# Altium schematic sheet SensorMod_Interface.SchDoc(SensorMod_Interface)
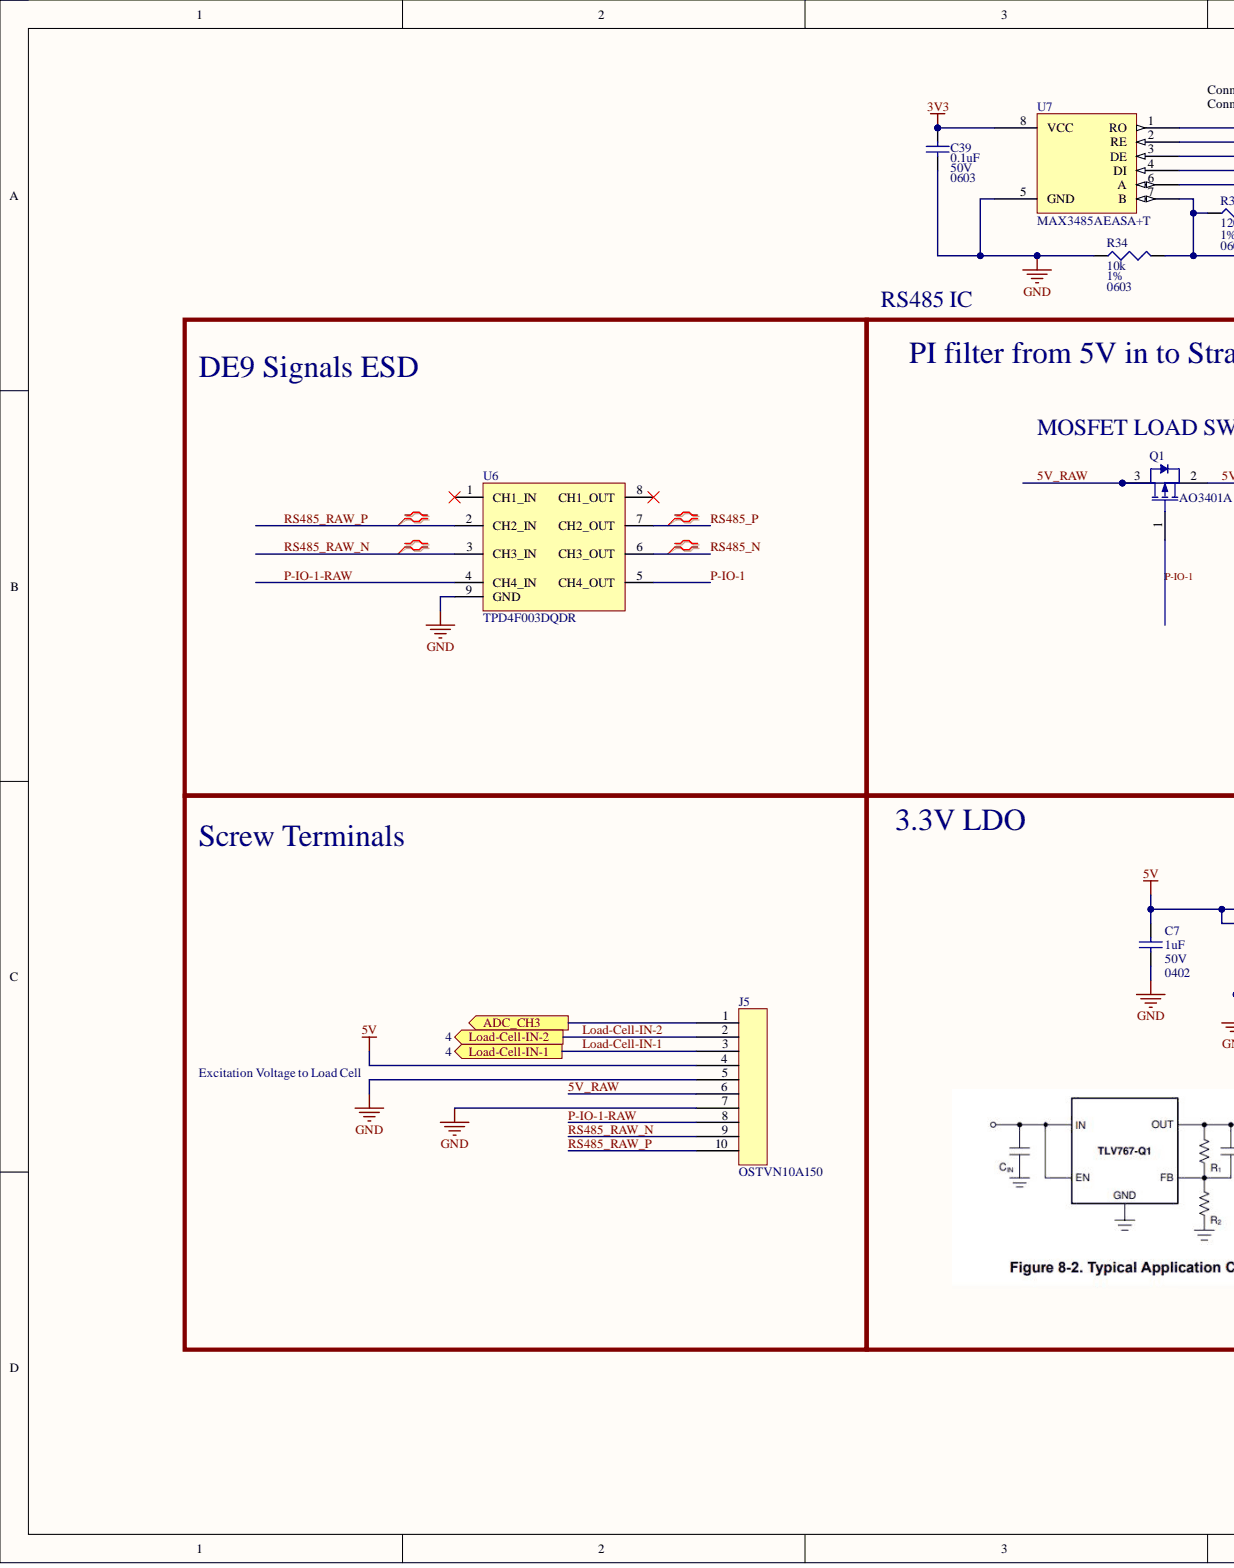
[diagram p0: SensorMod_Interface.SchDoc, left]
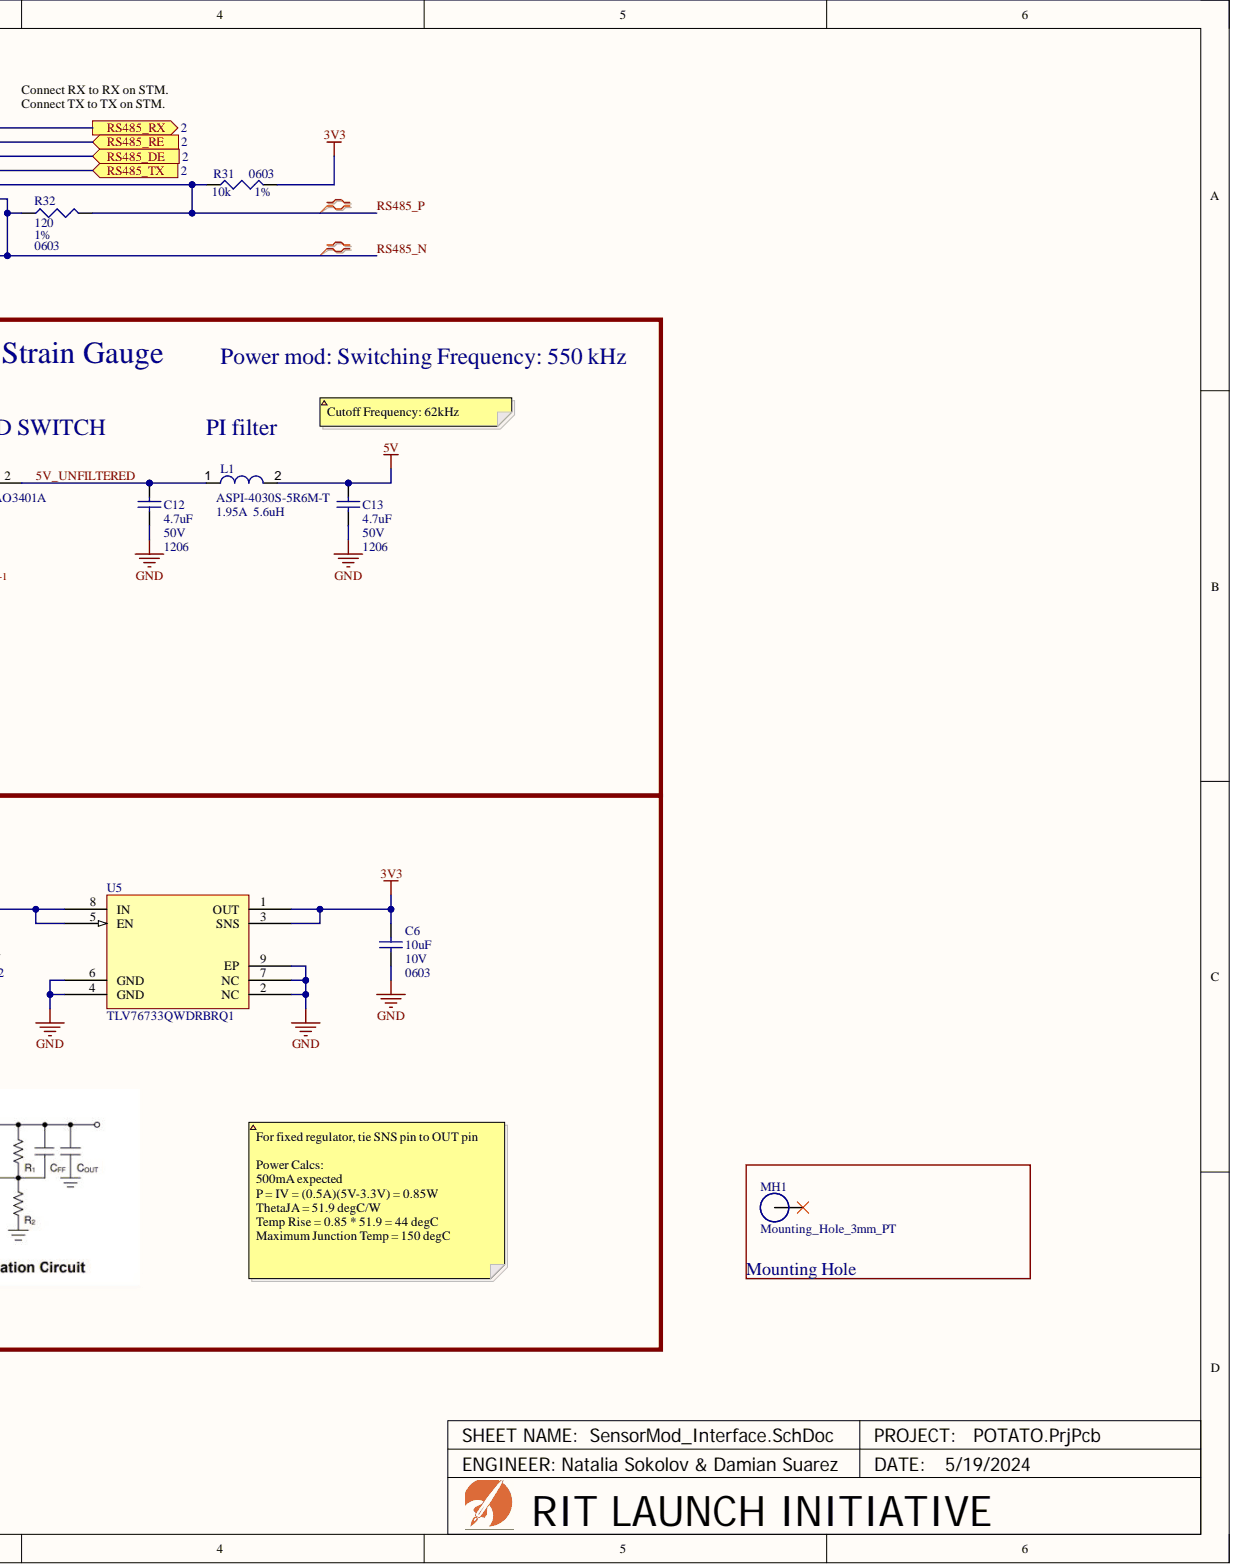
[diagram p1: SensorMod_Interface.SchDoc, right]

## Parts
C6  Capacitance: 10uF  Case/Package: 0603  Voltage: 10V  (hidden comment: CL10A106KP8NNNC)
C7  Capacitance: 1uF  Case/Package: 0402  Voltage: 50V  (hidden comment: CL05A105KA5NQNC)
C12  Capacitance: 4.7uF  Case/Package: 1206  Voltage: 50V  (hidden comment: 1206B475K500NT)
C13  Capacitance: 4.7uF  Case/Package: 1206  Voltage: 50V  (hidden comment: 1206B475K500NT)
C39  Capacitance: 0.1uF  Case Code (Imperial): 0603  Voltage: 50V  (hidden comment: CC0603KRX7R9BB104)
J5  OSTVN10A150
L1  ASPI-4030S-5R6M-T  Current Rating: 1.95A  Inductance: 5.6uH
MH1  Mounting_Hole_3mm_PT
Q1  AO3401A
R31  Case/Package: 0603  Resistance: 10k  Tolerance: 1%  (hidden comment: 0603WAF1002T5E)
R32  Case/Package: 0603  Tolerance: 1%  (hidden comment: 0603WAF1200T5E)
R34  Case/Package: 0603  Resistance: 10k  Tolerance: 1%  (hidden comment: 0603WAF1002T5E)
U5  TLV76733QWDRBRQ1
U6  TPD4F003DQDR
U7  MAX3485AEASA+T

## Ports
ADC_CH3, Load-Cell-IN-1, Load-Cell-IN-2, RS485_DE, RS485_RE, RS485_RX, RS485_TX

## Nets
3V3: C6.2 C39.2 R31.2 U5.1(OUT) U5.3(SNS) U7.8(VCC)
5V: C7.2 C13.2 J5.4 L1.2 U5.5(EN) U5.8(IN)
GND: C6.1 C7.1 C12.1 C13.1 C39.1 J5.5 J5.7 R34.1 U5.2(NC) U5.4(GND) U5.6(GND) U5.7(NC) U5.9(EP) U6.9(GND) U7.5(GND)
5V_RAW: J5.6 Q1.3
5V_UNFILTERED: C12.2 L1.1 Q1.2
ADC_CH3: J5.1 ; port ADC_CH3
Load-Cell-IN-1: J5.3 ; port Load-Cell-IN-1
Load-Cell-IN-2: J5.2 ; port Load-Cell-IN-2
P-IO-1: Q1.1 U6.5(CH4_OUT)
P-IO-1-RAW: J5.8 U6.4(CH4_IN)
RS485_DE: U7.3(DE) ; port RS485_DE
RS485_N: R32.1 R34.2 U6.6(CH3_OUT) U7.7(B)
RS485_P: R31.1 R32.2 U6.7(CH2_OUT) U7.6(A)
RS485_RAW_N: J5.9 U6.3(CH3_IN)
RS485_RAW_P: J5.10 U6.2(CH2_IN)
RS485_RE: U7.2(RE) ; port RS485_RE
RS485_RX: U7.1(RO) ; port RS485_RX
RS485_TX: U7.4(DI) ; port RS485_TX

Not connected: MH1 1; U6 1(CH1_IN) 8(CH1_OUT)

## Library data of the parts
C6 = CMP-001-0266-1: CAP CER 10UF 10V X5R 0603; Material: Ceramic; Tolerance: 10%; Component Kind: Standard; Construction: Flat; Contact Plating: Tin; Depth: 0.8mm; Dielectric: X5R; Height: 0.8mm; JLCPCB Part #: C19702; Lead Free: Lead Free; Length: 1.6mm; Max Operating Temperature: 85°C; Min Operating Temperature: -55°C; Mounting Technology: Surface Mount; Package Quantity: 1; Packaging: Tape and Reel; Radiation Hardening: No; RoHS Compliant: Yes; Series: CL; Thickness: 0.035inch; Width: 0.031inch; Library Name: RIT Launch Initiative
C7 = CMP-001-0296-1: CAP CER 1uF 50V X5R 0402; Material: Ceramic; Tolerance: 10%; Component Kind: Standard; Dielectric: X5R; JLCPCB Part #: C52923; Max Operating Temperature: 85°C; Min Operating Temperature: -55°C; Mounting Technology: Surface Mount; Series: CL; Library Name: RIT Launch Initiative
C12 C13 = CMP-001-0401-2: CAP CER 4.7UF 50V X7R 1206; Component Kind: Standard; JLCPCB Part #: C29823; Library Name: RIT Launch Initiative
C39 = CMP-001-0242-3: CAP CER 0.1UF 50V X7R 0603; Dielectric Material: Ceramic; Tolerance: 10%; Case Code (Metric): 1608; Case/Package: 0603; Component Kind: Standard; Depth: 0.8mm; Dielectric: X7R; Halogen Free: Halogen Free; Height: 0.8mm; JLCPCB Part #: C14663; Lead Free: Lead Free; Length: 0.063inch; Max Operating Temperature: 125°C; Min Operating Temperature: -55°C; Mount: Surface Mount; Number of Pins: 2; Package Quantity: 1; Packaging: Tape and Reel; Radiation Hardening: No; REACH SVHC: No SVHC; RoHS Compliant: Yes; Series: CC; Termination: SMD/SMT; Thickness: 0.035inch; Voltage Rating: 50V; Width: 0.031inch; Library Name: RIT Launch Initiative
J5 = CMP-001-0413-3: TERM BLK 10P SIDE ENT 2.54MM PCB; Additional Feature: STANDARD: UL 94V-0, THERMOPLASTIC; Current Rating: 6A; Fastening Method: SCREW; Number of Decks: 1; Number of Ways: 10; Safety Approval: UL,CUL; Voltage Rating: 125V; Wire/Cable Gauge: 20AWG; Component Kind: Standard; Mounting Technology: Board; Number of Rows: 1; Reach SVHC Compliant: Yes; RoHS Compliant: Yes; Terminal and Terminal Block Type: BARRIER STRIP TERMINAL BLOCK; Library Name: RIT Launch Initiative
L1 = CMP-001-0400-1: FIXED IND 5.6UH 1.95A 65MOHM SMD; Inductor Type: GENERAL PURPOSE INDUCTOR; Tolerance: 20%; Component Kind: Standard; JESD-609 Code: e3; Manufacturer Series: ASPI-4030S; Reach SVHC Compliant: Yes; RoHS Compliant: Yes; Terminal Finish: Tin; Library Name: RIT Launch Initiative
MH1 = CMP-001-0289-1: 3mm Screw, 6.5mm Head, Plated Mounting Hole; Component Kind: Standard; Library Name: RIT Launch Initiative
Q1 = CMP-001-0310-2: MOSFET P-CH 30V 4A SOT23-3L; Input Capacitance: 1.2nF; Max Power Dissipation: 1.4W; Number of Elements: 1; Rds On Max: 44mR; Case/Package: TO-236-3; Component Kind: Standard; Continuous Drain Current (ID): 4A; Drain to Source Voltage (Vdss): 30V; JLCPCB Part #: C15127; Lead Free: Lead Free; Max Operating Temperature: 150°C; Min Operating Temperature: -55°C; Mounting Technology: Surface Mount; Packaging: Cut Tape; Pins: 3; Power Dissipation: 1.4W; Radiation Hardening: No; RoHS Compliant: Yes; Series: -; Library Name: RIT Launch Initiative
R31 R34 = CMP-001-0268-1: RES 10K OHM 1% 1/10W 0603; Component Kind: Standard; JLCPCB Part #: C25804; RoHS Compliant: Yes; Library Name: RIT Launch Initiative
R32 = CMP-001-0272-1: RES 120 OHM 1% 1/10W 0603; Resistance: 120; Component Kind: Standard; JLCPCB Part #: C22787; Library Name: RIT Launch Initiative
U5 = CMP-001-0402-1: IC REG LIN 3.3V 1A 8SON; Component Kind: Standard; JLCPCB Part #: C5220143; RoHS Compliant: Yes; Library Name: RIT Launch Initiative
U6 = CMP-001-0365-2: 4 channel 5.5-V, ±12kV ESD protection & EMI Filter with 200-MHz -3-dB 8-WSON -40 to 85; Attenuation Value: 25dB; ESD Protection: Yes; Max Supply Voltage: 6V; Number of Channels: 4; Number of Elements: 8; Operating Supply Voltage: 5.5V; Value: 100R; Case/Package: SON; Circuit Type: Filter; Component Kind: Standard; Depth: 1.45mm; Height: 0.8mm; JLCPCB Part #: C398926; Lead Free: Lead Free; Length: 1.8mm; Max Operating Temperature: 85°C; Min Breakdown Voltage: 6V; Min Operating Temperature: -40°C; Package Quantity: 3000; Packaging: Tape and Reel; Pins: 8; Radiation Hardening: No; Reach SVHC Compliant: No; RoHS Compliant: Yes; Series: TPD; Termination: Flat; Width: 1.35mm; Library Name: RIT Launch Initiative
U7 = CMP-001-0317-1: IC TXRX RS422/485 3.3V 8SOIC; Component Kind: Standard; JLCPCB Part #: C94609; Library Name: RIT Launch Initiative
